# Altium schematic sheet Module microsd-card.SchDoc("Module microsd-card")
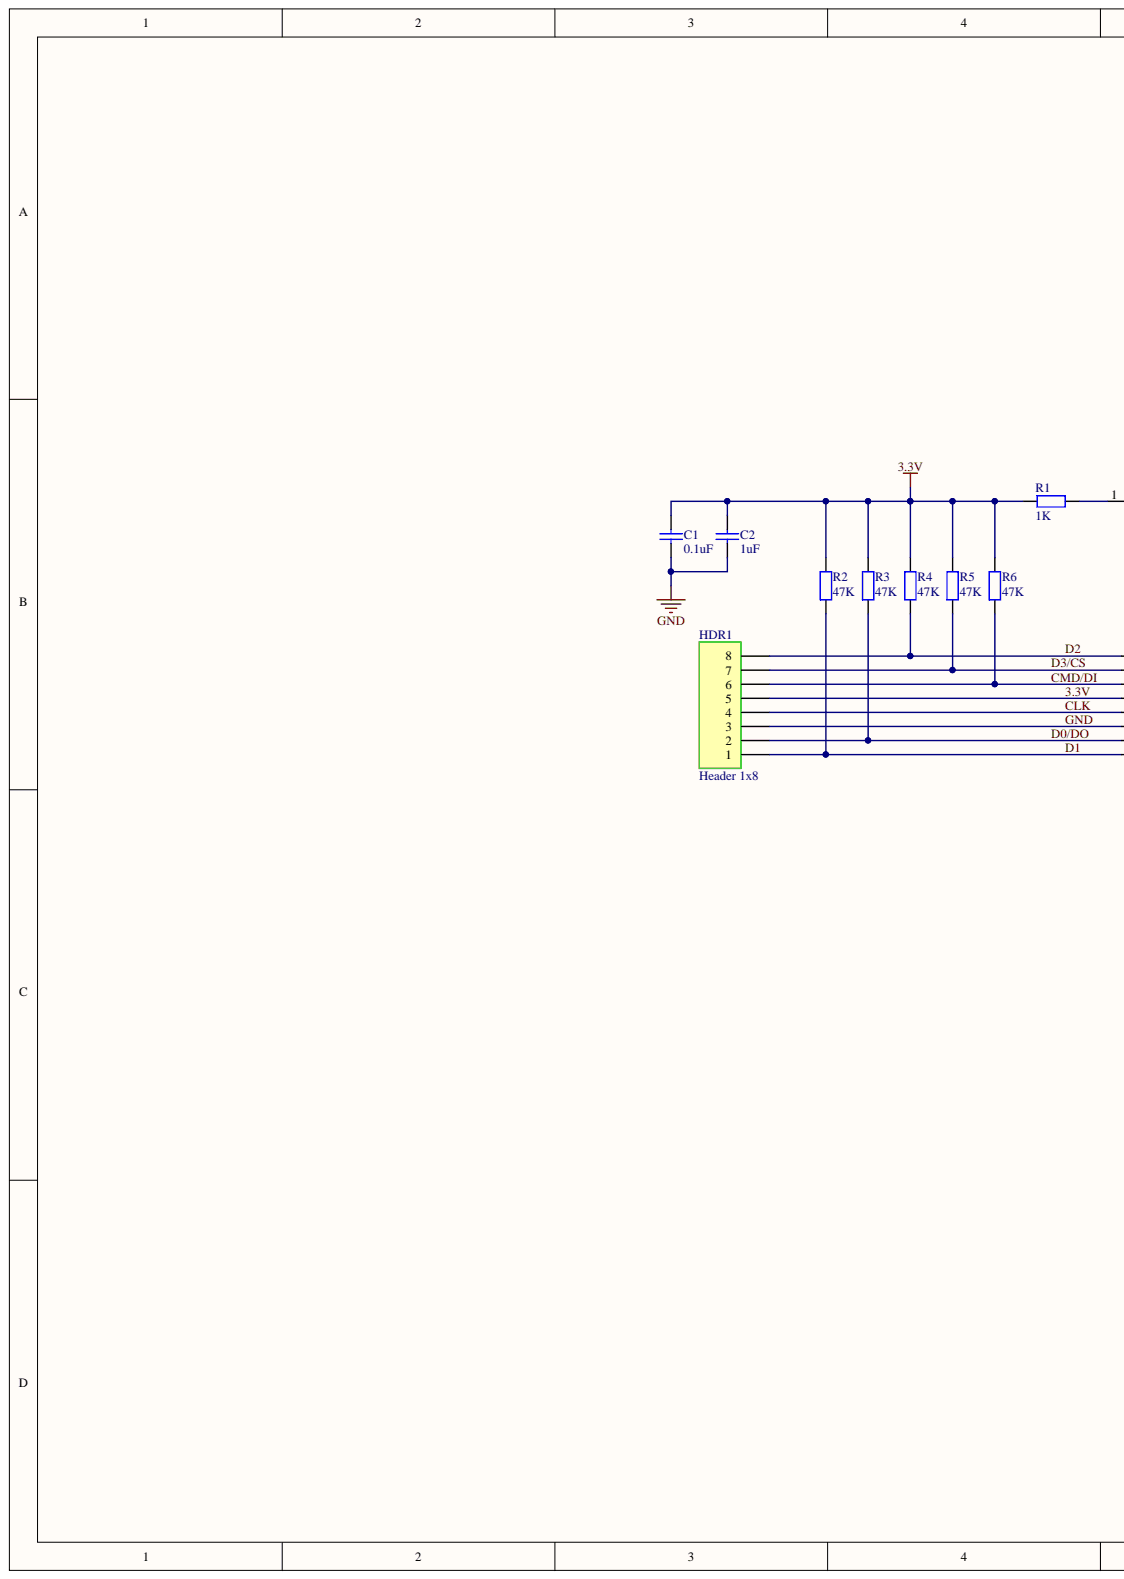
[diagram p0: Module microsd-card.SchDoc, left]
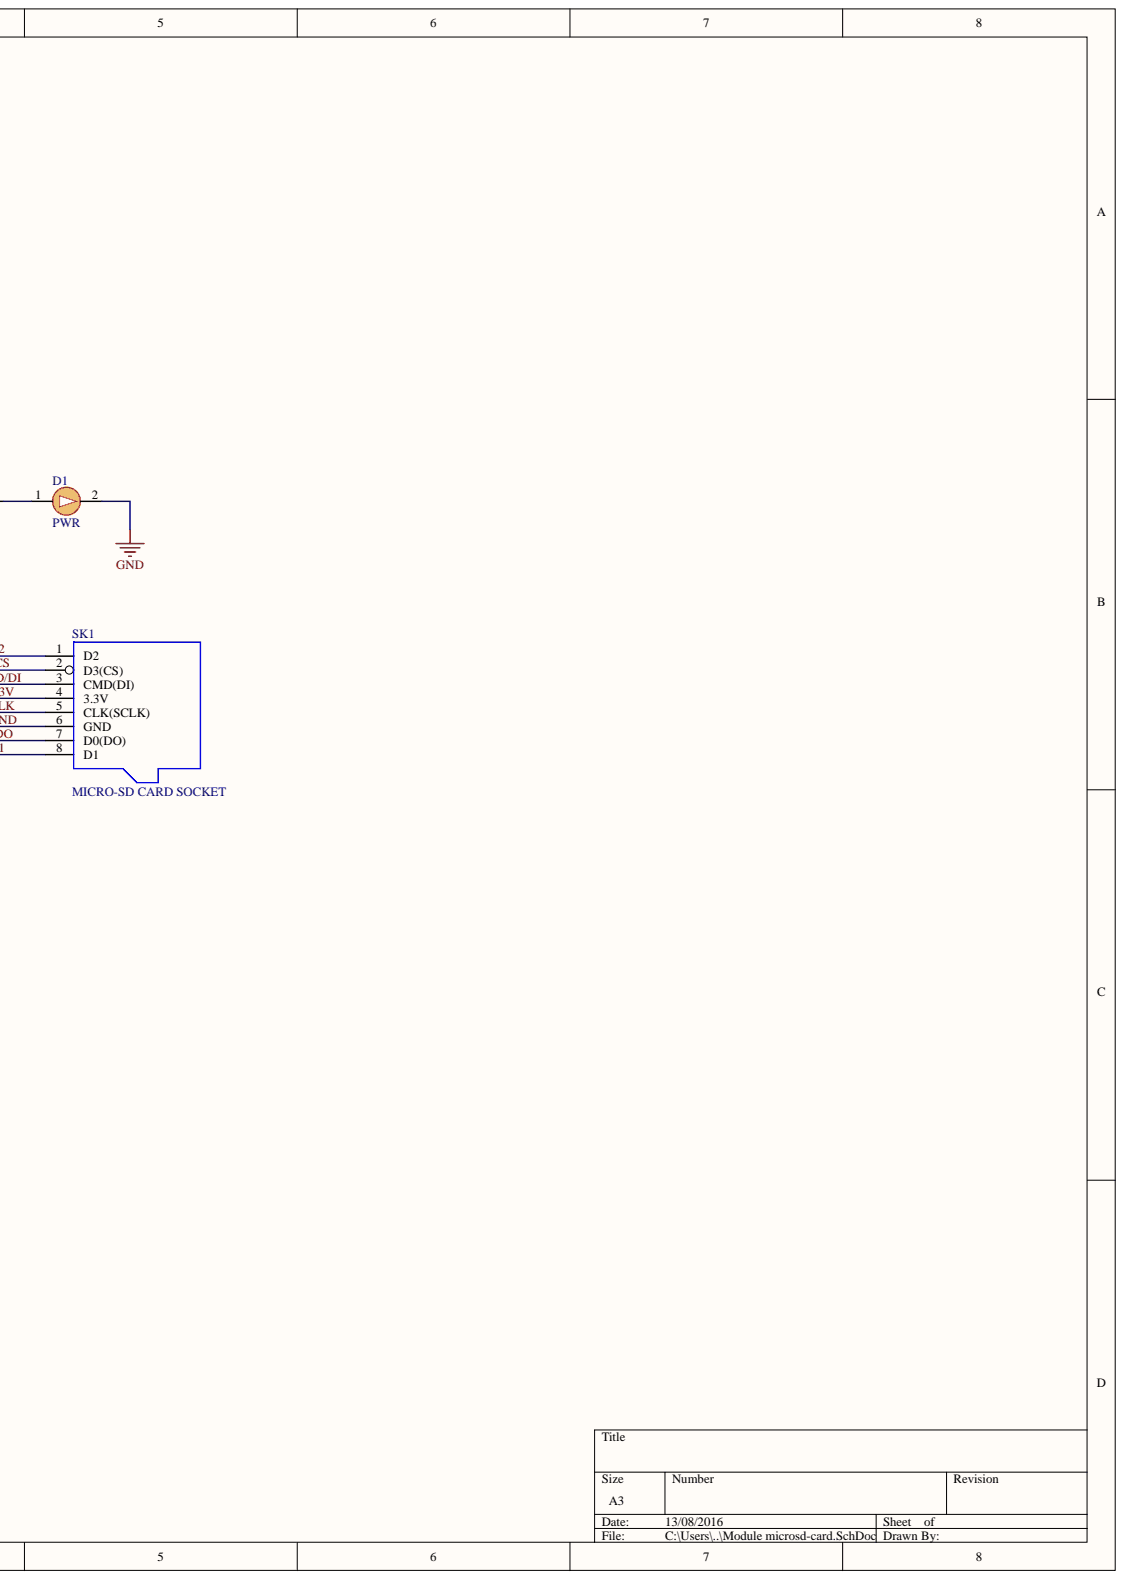
[diagram p1: Module microsd-card.SchDoc, right]

## Parts
C1  0.1uF  [0805_Thiep]
C2  1uF  [0805_Thiep]
D1  PWR  [Led-SMD]
HDR1  Header 1x8  [header1x8]
R1  1K  [0805_Thiep]
R2  47K  [0805_Thiep]
R3  47K  [0805_Thiep]
R4  47K  [0805_Thiep]
R5  47K  [0805_Thiep]
R6  47K  [0805_Thiep]
SK1  MICRO-SD CARD SOCKET  [microsd-socket TF3]

## Nets
3.3V: C1.2 C2.2 HDR1.5 R1.1 R2.2 R3.2 R4.2 R5.2 R6.2 SK1.4(3.3V)
CLK: HDR1.4 SK1.5(CLK(SCLK))
CMD/DI: HDR1.6 R6.1 SK1.3(CMD(DI))
D0/DO: HDR1.2 R3.1 SK1.7(D0(DO))
D1: HDR1.1 R2.1 SK1.8(D1)
D2: HDR1.8 R4.1 SK1.1(D2)
D3/CS: HDR1.7 R5.1 SK1.2(D3(CS))
GND: C1.1 C2.1 D1.2 HDR1.3 SK1.6(GND)
NetD1_1: D1.1 R1.2

## Library data of the parts
C1 C2 = Capacitor: Component Kind: Standard; Library Name: XuanThiep.SchLib
D1 = LED: Standard LED; Component Kind: Standard; Library Name: XuanThiep.SchLib
R1-R6 = Resistor: Dien tro; Component Kind: Standard; Library Name: XuanThiep.SchLib
SK1 = Micro-SD Card: Component Kind: Standard; Library Name: XuanThiep.SchLib
HDR1 = Header 1x8: Component Kind: Standard; Library Name: XuanThiep.SchLib
